# Altium schematic sheet Sheet1.SchDoc
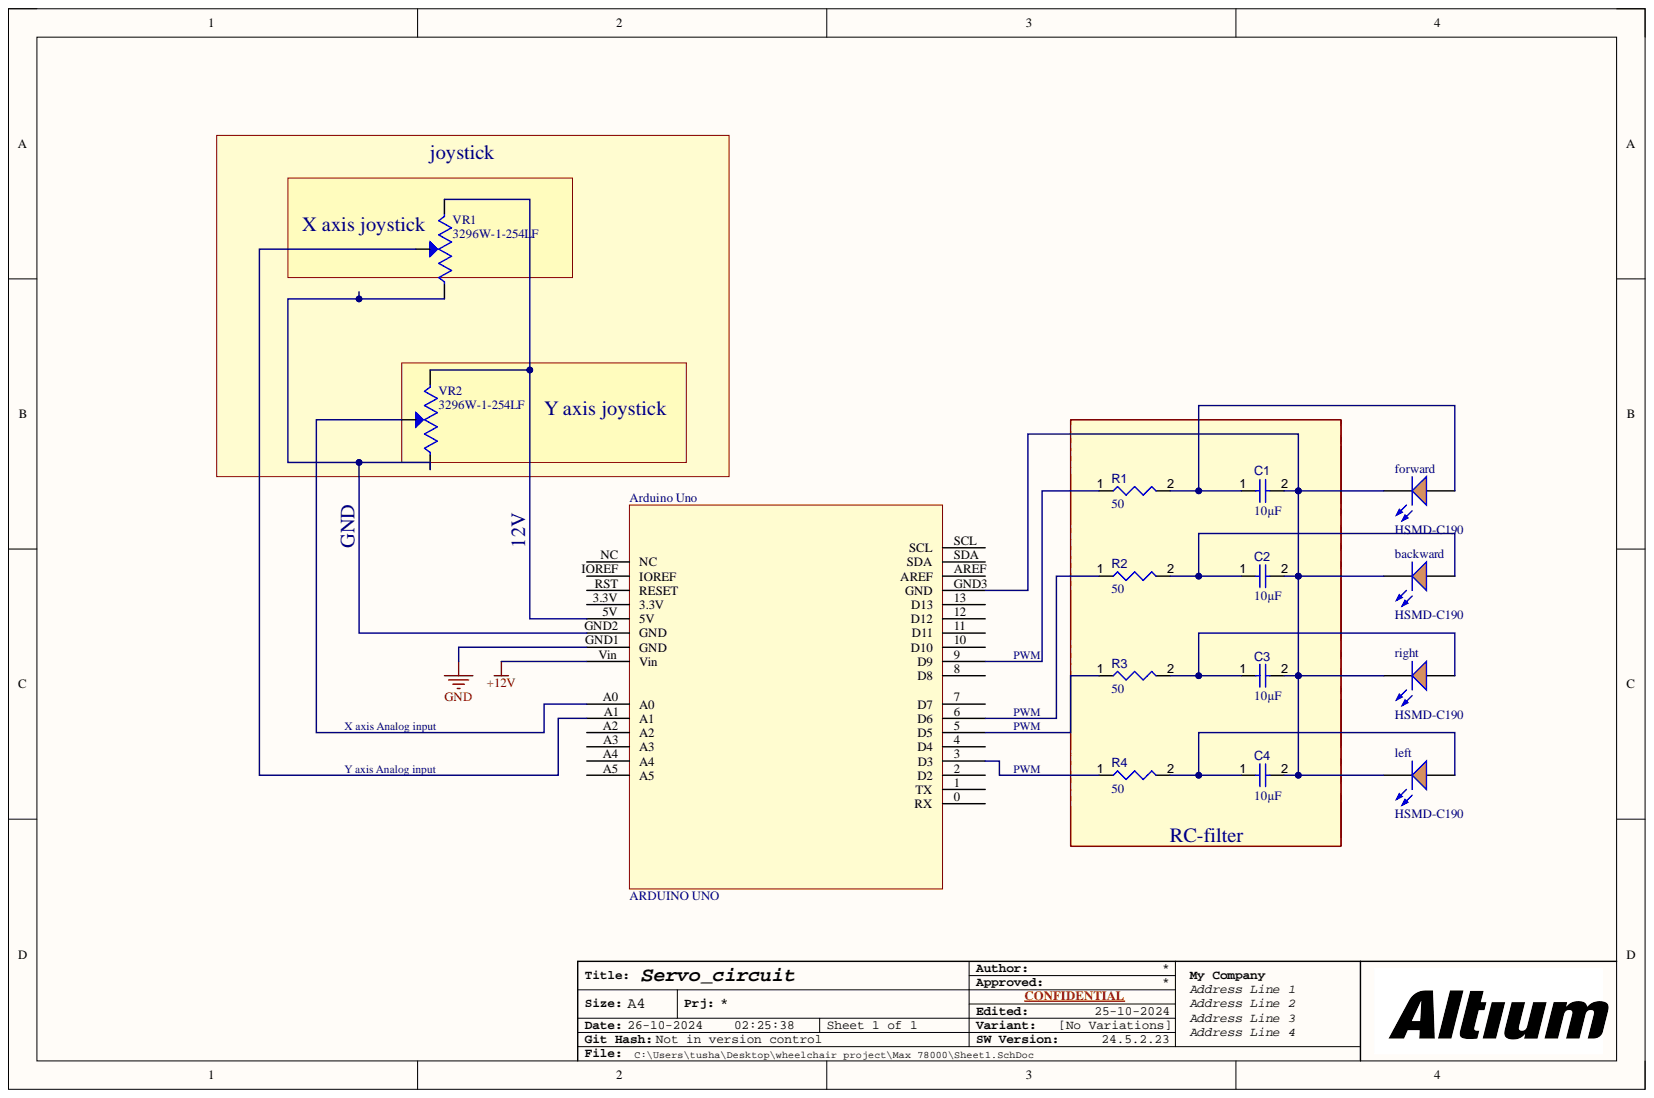
[diagram p0: Sheet1.SchDoc]

## Parts
Arduino_Uno  ARDUINO UNO  [ARDUINO UNO]
backward  HSMD-C190  [AVAG-HSMX-C190_V]
C1  value 10µF  [CAPC2013X135X45NL10T25]  (hidden comment: Capacitor 10 uF +/- 10% 16 V 0805)
C2  value 10µF  [CAPC2013X135X45NL10T25]  (hidden comment: Capacitor 10 uF +/- 10% 16 V 0805)
C3  value 10µF  [CAPC2013X135X45NL10T25]  (hidden comment: Capacitor 10 uF +/- 10% 16 V 0805)
C4  value 10µF  [CAPC2013X135X45NL10T25]  (hidden comment: Capacitor 10 uF +/- 10% 16 V 0805)
forward  HSMD-C190  [AVAG-HSMX-C190_V]
left  HSMD-C190  [AVAG-HSMX-C190_V]
R1  value 50  [RESC1005X06N]  (hidden comment: 33J50RE)
R2  value 50  [RESC1005X06N]  (hidden comment: 33J50RE)
R3  value 50  [RESC1005X06N]  (hidden comment: 33J50RE)
R4  value 50  [RESC1005X06N]  (hidden comment: 33J50RE)
right  HSMD-C190  [AVAG-HSMX-C190_V]
VR1  3296W-1-254LF  [TRIM_3296W-1-254LF]
VR2  3296W-1-254LF  [TRIM_3296W-1-254LF]

## Nets
+12V: Arduino_Uno.Vin
GND: Arduino_Uno.GND1(GND)
NetArduino Uno_3: Arduino_Uno.3(D3) R4.1
NetArduino Uno_5: Arduino_Uno.5(D5) R3.1
NetArduino Uno_5V: Arduino_Uno.5V VR1.3 VR2.3
NetArduino Uno_6: Arduino_Uno.6(D6) R2.1
NetArduino Uno_9: Arduino_Uno.9(D9) R1.1
NetArduino Uno_A0: Arduino_Uno.A0 VR2.2
NetArduino Uno_A1: Arduino_Uno.A1 VR1.2
NetArduino Uno_GND2: Arduino_Uno.GND2(GND) VR1.1 VR2.1
NetArduino Uno_GND3: Arduino_Uno.GND3(GND) backward.2 C1.2 C2.2 C3.2 C4.2 forward.2 left.2 right.2
Netbackward_1: backward.1 C2.1 R2.2
NetC1_1: C1.1 forward.1 R1.2
NetC3_1: C3.1 R3.2 right.1
NetC4_1: C4.1 left.1 R4.2

Not connected: Arduino_Uno 0(RX) 1(TX) 2(D2) 3.3V 4(D4) 7(D7) 8(D8) 10(D10) 11(D11) 12(D12) 13(D13) A2 A3 A4 A5 AREF IOREF NC RST(RESET) SCL SDA

## Library data of the parts
backward forward left right = CMP-007-00012-2: LED Uni-Color Orange, 52 mW, 20 mA, -40 to 85 degC, 2-Pin SMD, RoHS, Tape and Reel; Manufacturer: Avago; Forward Current: 20mA; Forward Voltage: 2.2V; Lens Style: Diffused; Luminous Intensity: 8mcd; Power: 52mW; Viewing Angle: 170degrees; Case/Package: 0603; Colour: Orange; Component Kind: Standard; Datasheet URL: http://docs.avagotech.com/docs/AV02-0551EN; Datasheet Version: Mar-12; Illumination Colour: Orange; Manufacturer URL: http://www.avagotech.com/; Max Operating Temperature: 85°C; Min Operating Temperature: -40°C; Mounting Technology: SM; Nominal Vf: 2.2V; Package Description: 2-Pin Surface Mount Device, Body 1.6 x 0.8 mm, Height 0.9 mm; Package Quantity: 4000; Package Reference: HSMX-C190; Packaging: TapeandReel; Pins: 2; RoHS Compliant: True; Library Name: Tushar Ranjan
C1-C4 = CMP-001-00015-6: Chip Capacitor, 10 uF, +/- 10%, 16 V, 0805 (2012 Metric); Capacitor Type: Ceramic; Voltage Rating: 16V; Case/Package: 0805; Component Kind: Standard; Max Operating Temperature: 85°C; Min Operating Temperature: -55°C; Mounting Technology: SM; Pins: 2; RoHS Compliant: True; Tolerance: 10%; Library Name: Tushar Ranjan
R1-R4 = CMP-009-00177-1: Fixed Resistor, Wire Wound, 3W, 50ohm, 200V, 5% +/-Tol, Through Hole Mount; Component Kind: Standard; Mounting Technology: Through Hole; Pins: 2; Power: 3W; RoHS Compliant: Yes; Simulation: 33J50RE-Simulation-1; Tolerance: 5%; Library Name: Tushar Ranjan
VR1 VR2 = 3296W-1-254LF: 250 kOhms 0.5W, 1/2W PC Pins Through Hole Trimmer Potentiometer Cermet 25.0 Turn Top Adjustment; MF: Bourns; Availability: In Stock; Check_prices: https://www.snapeda.com/parts/3296W-1-254LF/Bourns+-+J.W.+Miller/view-part/?ref=eda; Component Kind: Standard; Library Name: 3296W-1-254LF.IntLib; MP: 3296W-1-254LF; Package: SOT-23-5 Bourns; Price: None; Purchase-URL: https://pricing.snapeda.com/search/part/3296W-1-254LF/?ref=eda; SnapEDA_Link: https://www.snapeda.com/parts/3296W-1-254LF/Bourns+-+J.W.+Miller/view-part/?ref=snap
Arduino_Uno = ARDUINO UNO: Component Kind: Standard; Library Name: Arduino.IntLib
